# Altium schematic sheet PCIe_UART_Bridge.SchDoc(PCIe_UART_Bridge)
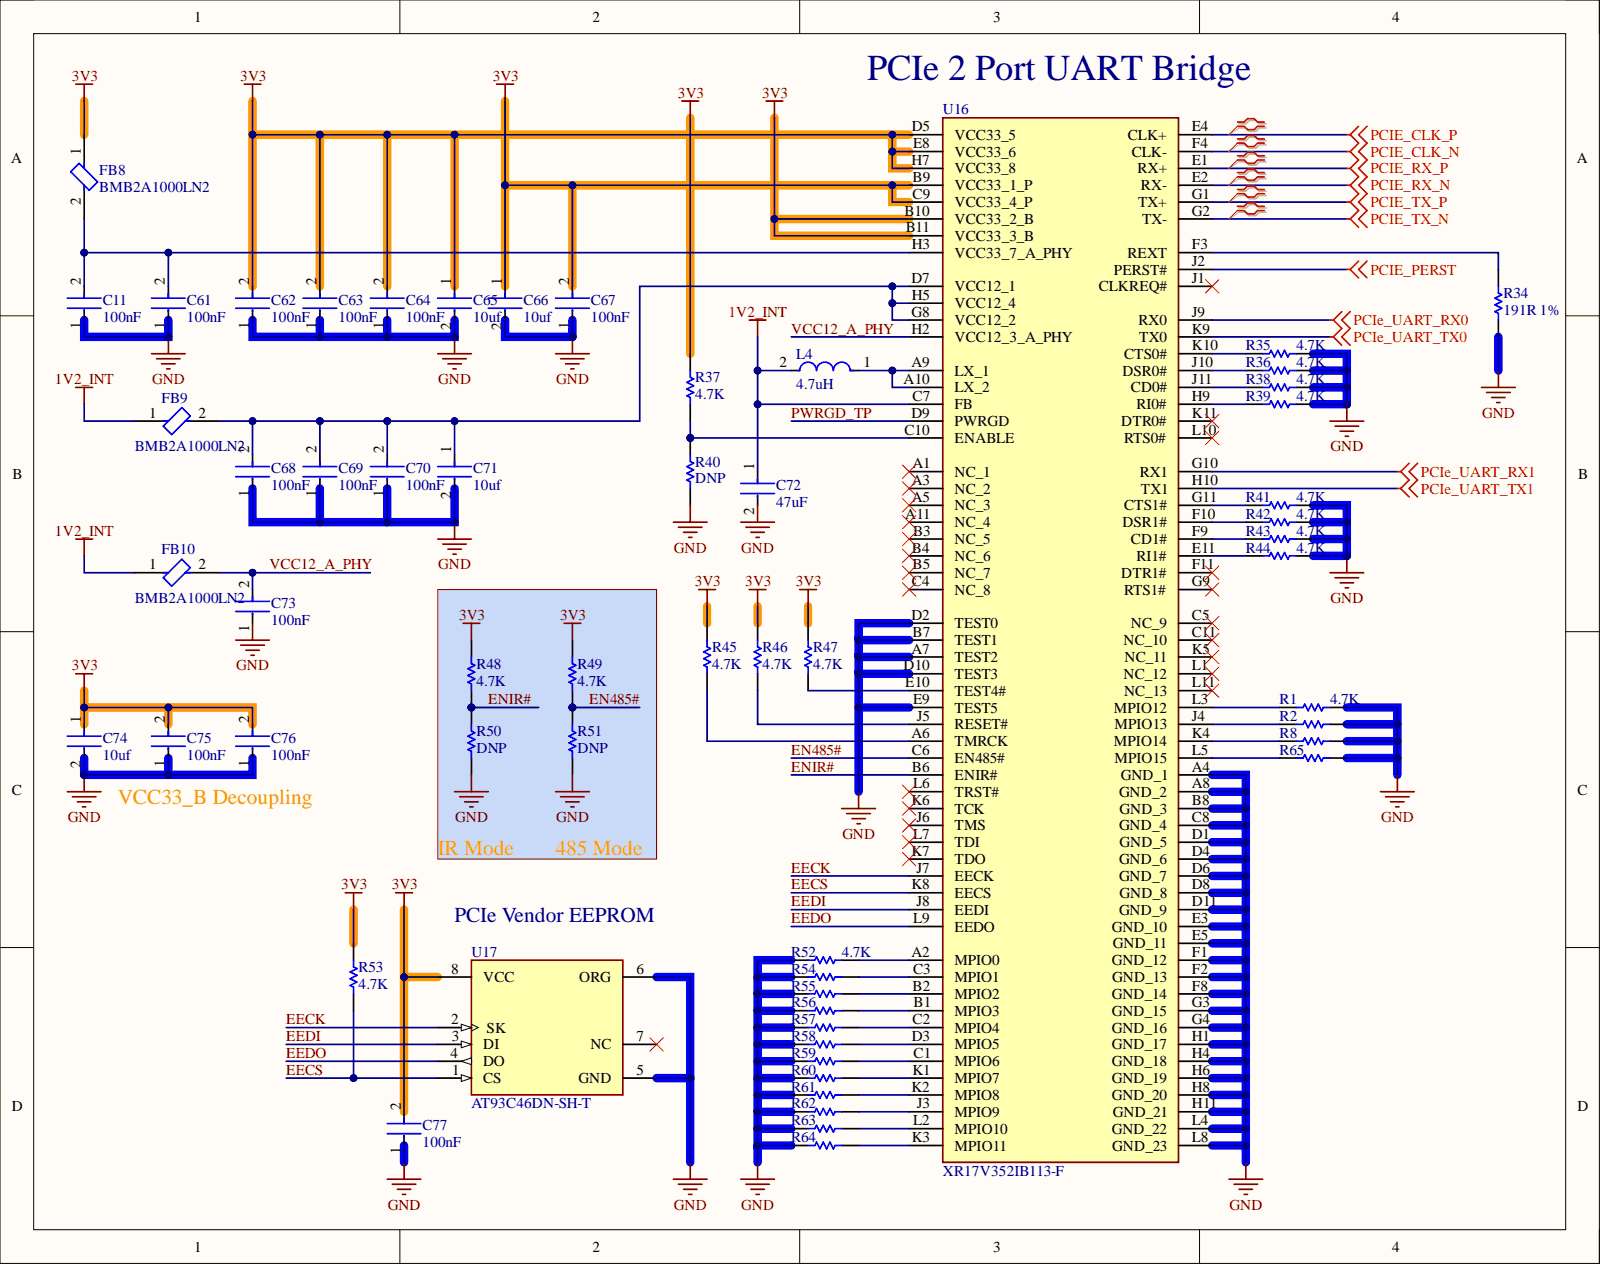
[diagram p0: PCIe_UART_Bridge.SchDoc]

## Parts
C11  100nF  [FP-GRM15-MFG]
C61  100nF  [FP-GRM15-MFG]
C62  100nF  [FP-GRM15-MFG]
C63  100nF  [FP-GRM15-MFG]
C64  100nF  [FP-GRM15-MFG]
C65  10uf  [FP-GRM188-0_2-IPC_C]
C66  10uf  [FP-GRM188-0_2-IPC_C]
C67  100nF  [FP-GRM15-MFG]
C68  100nF  [FP-GRM15-MFG]
C69  100nF  [FP-GRM15-MFG]
C70  100nF  [FP-GRM15-MFG]
C71  10uf  [FP-GRM188-0_2-IPC_C]
C72  47uF  [FP-C2012-125-0_2-IPC_B]
C73  100nF  [FP-GRM15-MFG]
C74  10uf  [FP-GRM188-0_2-IPC_C]
C75  100nF  [FP-GRM15-MFG]
C76  100nF  [FP-GRM15-MFG]
C77  100nF  [FP-GRM15-MFG]
FB8  BMB2A1000LN2  [FP-BMB2A1000LN2-IPC_C]
FB9  BMB2A1000LN2  [FP-BMB2A1000LN2-IPC_C]
FB10  BMB2A1000LN2  [FP-BMB2A1000LN2-IPC_C]
L4  4.7uH  [FP-NR3012-MFG]
R1  4.7K  [RESC1005X40X25LL05T05]
R2  4.7K  [RESC1005X40X25LL05T05]
R8  4.7K  [RESC1005X40X25LL05T05]  (value not drawn)
R34  191R 1%  [RESC1005X40X25ML05T05]
R35  4.7K  [RESC1005X40X25LL05T05]
R36  4.7K  [RESC1005X40X25LL05T05]
R37  4.7K  [RESC1005X40X25LL05T05]
R38  4.7K  [RESC1005X40X25LL05T05]
R39  4.7K  [RESC1005X40X25LL05T05]
R40  DNP  [RESC1005X40X25LL05T05]
R41  4.7K  [RESC1005X40X25LL05T05]
R42  4.7K  [RESC1005X40X25LL05T05]
R43  4.7K  [RESC1005X40X25LL05T05]
R44  4.7K  [RESC1005X40X25LL05T05]
R45  4.7K  [RESC1005X40X25LL05T05]
R46  4.7K  [RESC1005X40X25LL05T05]
R47  4.7K  [RESC1005X40X25LL05T05]
R48  4.7K  [RESC1005X40X25LL05T05]
R49  4.7K  [RESC1005X40X25LL05T05]
R50  DNP  [RESC1005X40X25LL05T05]
R51  DNP  [RESC1005X40X25LL05T05]
R52  4.7K  [RESC1005X40X25LL05T05]
R53  4.7K  [RESC1005X40X25LL05T05]
R54  4.7K  [RESC1005X40X25LL05T05]
R55  4.7K  [RESC1005X40X25LL05T05]  (value not drawn)
R56  4.7K  [RESC1005X40X25LL05T05]  (value not drawn)
R57  4.7K  [RESC1005X40X25LL05T05]  (value not drawn)
R58  4.7K  [RESC1005X40X25LL05T05]  (value not drawn)
R59  4.7K  [RESC1005X40X25LL05T05]  (value not drawn)
R60  4.7K  [RESC1005X40X25LL05T05]  (value not drawn)
R61  4.7K  [RESC1005X40X25LL05T05]  (value not drawn)
R62  4.7K  [RESC1005X40X25LL05T05]  (value not drawn)
R63  4.7K  [RESC1005X40X25LL05T05]  (value not drawn)
R64  4.7K  [RESC1005X40X25LL05T05]  (value not drawn)
R65  4.7K  [RESC1005X40X25LL05T05]  (value not drawn)
U16  XR17V352IB113-F  [BGA113C80P11X11_900X900X153]
U17  AT93C46DN-SH-T  [FP-C04-057-SN-MFG]

## Nets
1V2_INT: C72.1 FB9.1 FB10.1 L4.2 U16.C7(FB)
3V3: C62.2 C63.2 C64.2 C65.1 C66.1 C67.2 C74.1 C75.2 C76.2 C77.2 FB8.1 R37.2 R45.2 R46.2 R47.2 R48.2 R49.2 R53.2 U16.B9(VCC33_1_P) U16.B10(VCC33_2_B) U16.B11(VCC33_3_B) U16.C9(VCC33_4_P) U16.D5(VCC33_5) U16.E8(VCC33_6) U16.H7(VCC33_8) U17.8(VCC)
GND: C11.1 C61.1 C62.1 C63.1 C64.1 C65.2 C66.2 C67.1 C68.1 C69.1 C70.1 C71.2 C72.2 C73.1 C74.2 C75.1 C76.1 C77.1 R1.1 R2.1 R8.1 R34.2 R35.1 R36.1 R38.1 R39.1 R40.1 R41.1 R42.1 R43.1 R44.1 R50.1 R51.1 R52.2 R54.2 R55.2 R56.2 R57.2 R58.2 R59.2 R60.2 R61.2 R62.2 R63.2 R64.2 R65.1 U16.A4(GND_1) U16.A7(TEST2) U16.A8(GND_2) U16.B7(TEST1) U16.B8(GND_3) U16.C8(GND_4) U16.D1(GND_5) U16.D2(TEST0) U16.D4(GND_6) U16.D6(GND_7) U16.D8(GND_8) U16.D10(TEST3) U16.D11(GND_9) U16.E3(GND_10) U16.E5(GND_11) U16.E9(TEST5) U16.F1(GND_12) U16.F2(GND_13) U16.F8(GND_14) U16.G3(GND_15) U16.G4(GND_16) U16.H1(GND_17) U16.H4(GND_18) U16.H6(GND_19) U16.H8(GND_20) U16.H11(GND_21) U16.L4(GND_22) U16.L8(GND_23) U17.5(GND) U17.6(ORG)
PCIE_CLK_N: U16.F4(CLK-)
PCIE_CLK_P: U16.E4(CLK+)
PCIE_PERST: U16.J2(PERST#)
PCIE_RX_N: U16.E2(RX-)
PCIE_RX_P: U16.E1(RX+)
PCIE_TX_N: U16.G2(TX-)
PCIE_TX_P: U16.G1(TX+)
PCIe_UART_RX0: U16.J9(RX0)
PCIe_UART_RX1: U16.G10(RX1)
PCIe_UART_TX0: U16.K9(TX0)
PCIe_UART_TX1: U16.H10(TX1)
EECK: U16.J7(EECK) U17.2(SK)
EECS: R53.1 U16.K8(EECS) U17.1(CS)
EEDI: U16.J8(EEDI) U17.3(DI)
EEDO: U16.L9(EEDO) U17.4(DO)
EN485#: R49.1 R51.2 U16.C6(EN485#)
ENIR#: R48.1 R50.2 U16.B6(ENIR#)
PWRGD_TP: U16.D9(PWRGD)
VCC12_A_PHY: C73.2 FB10.2 U16.H2(VCC12_3_A_PHY)
NetC11_2: C11.2 C61.2 FB8.2 U16.H3(VCC33_7_A_PHY)
NetC68_2: C68.2 C69.2 C70.2 C71.1 FB9.2 U16.D7(VCC12_1) U16.G8(VCC12_2) U16.H5(VCC12_4)
NetL4_1: L4.1 U16.A9(LX_1) U16.A10(LX_2)
NetR1_2: R1.2 U16.L3(MPIO12)
NetR2_2: R2.2 U16.J4(MPIO13)
NetR8_2: R8.2 U16.K4(MPIO14)
NetR34_1: R34.1 U16.F3(REXT)
NetR35_2: R35.2 U16.K10(CTS0#)
NetR36_2: R36.2 U16.J10(DSR0#)
NetR37_1: R37.1 R40.2 U16.C10(ENABLE)
NetR38_2: R38.2 U16.J11(CD0#)
NetR39_2: R39.2 U16.H9(RI0#)
NetR41_2: R41.2 U16.G11(CTS1#)
NetR42_2: R42.2 U16.F10(DSR1#)
NetR43_2: R43.2 U16.F9(CD1#)
NetR44_2: R44.2 U16.E11(RI1#)
NetR45_1: R45.1 U16.A6(TMRCK)
NetR46_1: R46.1 U16.J5(RESET#)
NetR47_1: R47.1 U16.E10(TEST4#)
NetR52_1: R52.1 U16.A2(MPIO0)
NetR54_1: R54.1 U16.C3(MPIO1)
NetR55_1: R55.1 U16.B2(MPIO2)
NetR56_1: R56.1 U16.B1(MPIO3)
NetR57_1: R57.1 U16.C2(MPIO4)
NetR58_1: R58.1 U16.D3(MPIO5)
NetR59_1: R59.1 U16.C1(MPIO6)
NetR60_1: R60.1 U16.K1(MPIO7)
NetR61_1: R61.1 U16.K2(MPIO8)
NetR62_1: R62.1 U16.J3(MPIO9)
NetR63_1: R63.1 U16.L2(MPIO10)
NetR64_1: R64.1 U16.K3(MPIO11)
NetR65_2: R65.2 U16.L5(MPIO15)

Not connected: U16 A1(NC_1) A3(NC_2) A5(NC_3) A11(NC_4) B3(NC_5) B4(NC_6) B5(NC_7) C4(NC_8) C5(NC_9) C11(NC_10) F11(DTR1#) G9(RTS1#) J1(CLKREQ#) J6(TMS) K5(NC_11) K6(TCK) K7(TDO) K11(DTR0#) L1(NC_12) L6(TRST#) L7(TDI) L10(RTS0#) L11(NC_13); U17 7(NC)

## Library data of the parts
C11 C61-C64 C67-C70 C73 C75-C77 = CMP-2100-03641-2: CAP CER 0.1UF 16V X7R 0402; Manufacturer: Murata; Manufacturer Part Number: GRM155R71C104KA88D; Capacitance: 0.10μF; Material: Ceramic; Standoff Height: 0mm; Tolerance: 10%; Value: 0.10μF; Voltage Rating: 16Vdc; Category: Cap; Component Kind: Standard; ComponentLink2Description: Datasheet; ComponentLink2URL: https://search.murata.co.jp/Ceramy/image/img/A01X/G101/ENG/GRM155R71H471KA01-01A.pdf; Device Class L1: Passive Components; Device Class L2: Capacitors; Device Class L3: Ceramic Capacitors; Digikey Description: CAP CER 0.1UF 16V X7R 0402; Height: 0.55mm; Ipc Land Pattern Name: CAPC10050X219; Lead Free: yes; Library Name: Altium Content Vault; Mouser Description: 0402 0.1 uF 16V ±10 % Tolerance X7R SMT Multilayer Ceramic Capacitor; Octopart: https://octopart.com/grm155r71c104ka88d-murata-196880; Package: GRM15; RoHS: TRUE; Temperature: -55°C to +125°C; Temperature Characteristic: X7R; Temperature Range High: +125°C; Temperature Range Low: -55°C
C65 C66 C71 C74 = CMP-06035-045466-1: Chip Multilayer Ceramic Capacitors for General Purpose, 0603, 10uF, X5R, 15%, 20%, 35V; Capacitance: 10uF; Case Code (Imperial): 0603; Case Code (Metric): 1608; Case/Package: 0603; Component Kind: Standard; Dielectric: X5R; Length: 0.063inch; Library Name: Altium Content Vault; Max Operating Temperature: 85°C; Min Operating Temperature: -55°C; Mount: Surface Mount; Packaging: Tape and Reel; Thickness: 0.039inch; Tolerance: 20%; Voltage Rating: 35V; Voltage Rating (DC): 35V; Width: 0.031inch
C72 = CMP-2000-05451-2: Multilayer Ceramic Capacitors 47μF ±20% 10V X5R SMD 0805; Capacitance: 47uF; Case Code (Imperial): 0805; Case Code (Metric): 2012; Case/Package: 0805; Component Kind: Standard; Depth: 1.25mm; Dielectric: X5R; Dielectric Material: Ceramic; Height: 1.25mm; Lead Free: Lead Free; Length: 2mm; Library Name: Altium Content Vault; Max Operating Temperature: 85°C; Min Operating Temperature: -55°C; Mount: Surface Mount; Package Quantity: 1; Packaging: Tape and Reel; REACH SVHC: No SVHC; Resistor Type: Ceramic; RoHS Compliant: Yes; Series: C; Termination: SMD/SMT; Thickness: 0.057inch; Tolerance: 20%; Voltage: 10V; Voltage Rating: 10V; Voltage Rating (DC): 10V; Weight: 0.000194oz; Width: 0.049inch
FB8-FB10 = CMP-03232-000001-1: FERRITE BEAD 1 KOHM 0805 1LN; DC Resistance (DCR): 300mR; Impedance: 1kR; Lead/Base Style: SMD/SMT; Max Current Rating: 350mA; Number of Lines: 1; Tolerance: 25%; Case Code (Imperial): 0805; Case Code (Metric): 2012; Case/Package: 0805; Component Kind: Standard; Core Material: Ferrite; Depth: 1.4mm; ELV: Compliant; Height: 1.1mm; Library Name: Altium Content Vault; Max DC Current: 350mA; Max Operating Temperature: 125°C; Min Operating Temperature: -55°C; Mount: Surface Mount; Number of Pins: 2; Packaging: Tape and Reel; Resistor Type: Ferrite Bead; RoHS Compliant: Yes; Series: BMB-L
L4 = CMP-14484-001484-1: SMD Power Inductors 4.7uH ±20% 0.77A 0.13Ω; Core Material: Ferrite; Current Rating: 980mA; DC Resistance (DCR): 156mR; Max DC Current: 770mA; Self Resonant Frequency: 48MHz; Shielding: Shielded; Test Frequency: 100kHz; Case Code (Imperial): 1212; Case Code (Metric): 3030; Case/Package: 1212; Component Kind: Standard; DC Current: 980mA; Depth: 3.1mm; Halogen Free: Halogen Free; Height: 1.2mm; Height - Seated (Max): 0.047inch; Inductance: 4.7uH; Inductance Tolerance: 20%; Lead Free: Lead Free; Length: 0.118inch; Library Name: Altium Content Vault; Max Operating Temperature: 120°C; Military Standard: Not; Min Operating Temperature: -25°C; Mount: Surface Mount; Package Quantity: 2000; Packaging: Tape and Reel; Radiation Hardening: No; Resistor Type: Wirewound; RMS Current (Irms): 980mA; RoHS Compliant: Yes; Saturation Current: 770mA; Series: NR; Termination: SMD/SMT; Tolerance: 20%; Width: 0.118inch
R1 R2 R8 R35-R39 R41-R65 = CMP-2002-01154-4: Chip Resistor, 4.7 KOhm, +/- 1%, 0.1 W, -55 to 155 degC, 0402 (1005 Metric), RoHS, Tape and Reel; PartNumber: ERJ-2RKF4701X; Case\/Package: 0402; Power Rating: 100 mW; Voltage Rating: 50 V; Case Code (Imperial): 0402; Case Code (Metric): 1005; Component Kind: Standard; ComponentLink1Description: Manufacturer URL; ComponentLink1URL: http://www.panasonic.com/; ComponentLink2Description: Datasheet; ComponentLink2URL: http://industrial.panasonic.com/cdbs/www-data/pdf/RDA0000/AOA0000C86.pdf; DatasheetVersion: 04/2015; Height: 0.35 mm; Length: 1 mm; Library Name: Altium Content Vault; Max Operating Temperature: 155 degC; Min Operating Temperature: -55 degC; Mount: Surface Mount; Mounting Technology: Surface Mount; Package Quantity: 1; PackageDescription: 2-Pin Surface Mount Device, Body 1 x 0.5 mm; PackageReference: 0402; Packaging: Cut Tape; Pins: 2; Radiation Hardening: No; REACH SVHC: Yes; Resistance: 4.7 KOhm; RoHSCompliant: true; Tolerance: 1%; Width: 0.5 mm
R34 = CMP-1011-00427-2: Manufacturer: Panasonic; Part Number: ERJ-2RKF1910X; Component Kind: Standard; Library Name: Altium Content Vault
R40 = CMP-2002-01154-4: PartNumber: ERJ-2RKF4701X; Case\/Package: 0402; Power Rating: 100 mW; Resistance: 4.7 KOhm; Tolerance: 1%; Voltage Rating: 50 V; Case Code (Imperial): 0402; Case Code (Metric): 1005; Component Kind: Standard; ComponentLink1Description: Manufacturer URL; ComponentLink1URL: http://www.panasonic.com/; ComponentLink2Description: Datasheet; ComponentLink2URL: http://industrial.panasonic.com/cdbs/www-data/pdf/RDA0000/AOA0000C86.pdf; DatasheetVersion: 04/2015; Description: DNP; Height: 0.35 mm; Length: 1 mm; Library Name: Altium Content Vault; Max Operating Temperature: 155 degC; Min Operating Temperature: -55 degC; Mount: Surface Mount; Mounting Technology: Surface Mount; Package Quantity: 1; PackageDescription: 2-Pin Surface Mount Device, Body 1 x 0.5 mm; PackageReference: 0402; Packaging: Cut Tape; Pins: 2; Radiation Hardening: No; REACH SVHC: Yes; RoHSCompliant: true; Width: 0.5 mm
U16 = XR17V352IB113-F: Integrated Circuit; Manufacturer_Name: MaxLinear; Arrow Part Number: XR17V352IB113-F; Arrow Price/Stock: https://www.arrow.com/en/products/xr17v352ib113-f/maxlinear-inc?region=nac; Component Kind: Standard; Datasheet Link: https://www.mouser.com/datasheet/2/146/XR17V352-536180.pdf; Height: 1.53mm; Library Name: SamacSys.SchLib; Manufacturer_Part_Number: XR17V352IB113-F; Mouser Part Number: 701-XR17V352IB113-F; Mouser Price/Stock: https://www.mouser.co.uk/ProductDetail/MaxLinear/XR17V352IB113-F?qs=j9wFvaVy6jcT7uc4HigktQ%3D%3D
U17 = CMP-06313-000016-1: IC EEPROM 1K SPI 2MHZ 8SOIC; Manufacturer: Microchip; Access Time: 1000ns; Interface: Other; Max Supply Voltage: 5.5V; Memory Size: 1Kbits; Min Supply Voltage: 1.8V; Nominal Supply Current: 0.5mA; Standoff Height: 0.1mm; Automotive: No; Category: IC; Component Kind: Standard; ComponentLink2Description: Datasheet; ComponentLink2URL: http://ww1.microchip.com/downloads/en/DeviceDoc/20006224B.pdf; Device Class L1: Integrated Circuits (ICs); Device Class L2: Memory; Device Class L3: EEPROM; Digikey Description: IC EEPROM 1K SPI 2MHZ 8SOIC; Frequency: 2MHz; Height: 1.75mm; Ipc Land Pattern Name: SOIC127P600X175-8; Lead Free: Yes; Library Name: Altium Content Vault; Manufacturer Part Number: AT93C46DN-SH-T; Memory Type: EEPROM; Mouser Description: EEPROM Serial-3Wire 1K-Bit 128 x 8/64 x 16 2.5V/3.3V/5V 8-Pin SOIC T/R; Octopart: https://octopart.com/at93c46dn-sh-t-microchip-77759177; Package: C04-057-SN; RoHS: TRUE; Temperature: -40°C to +85°C; Temperature Range High: +85°C; Temperature Range Low: -40°C
